# Altium schematic sheet mcu_main.SchDoc(mcu_main)
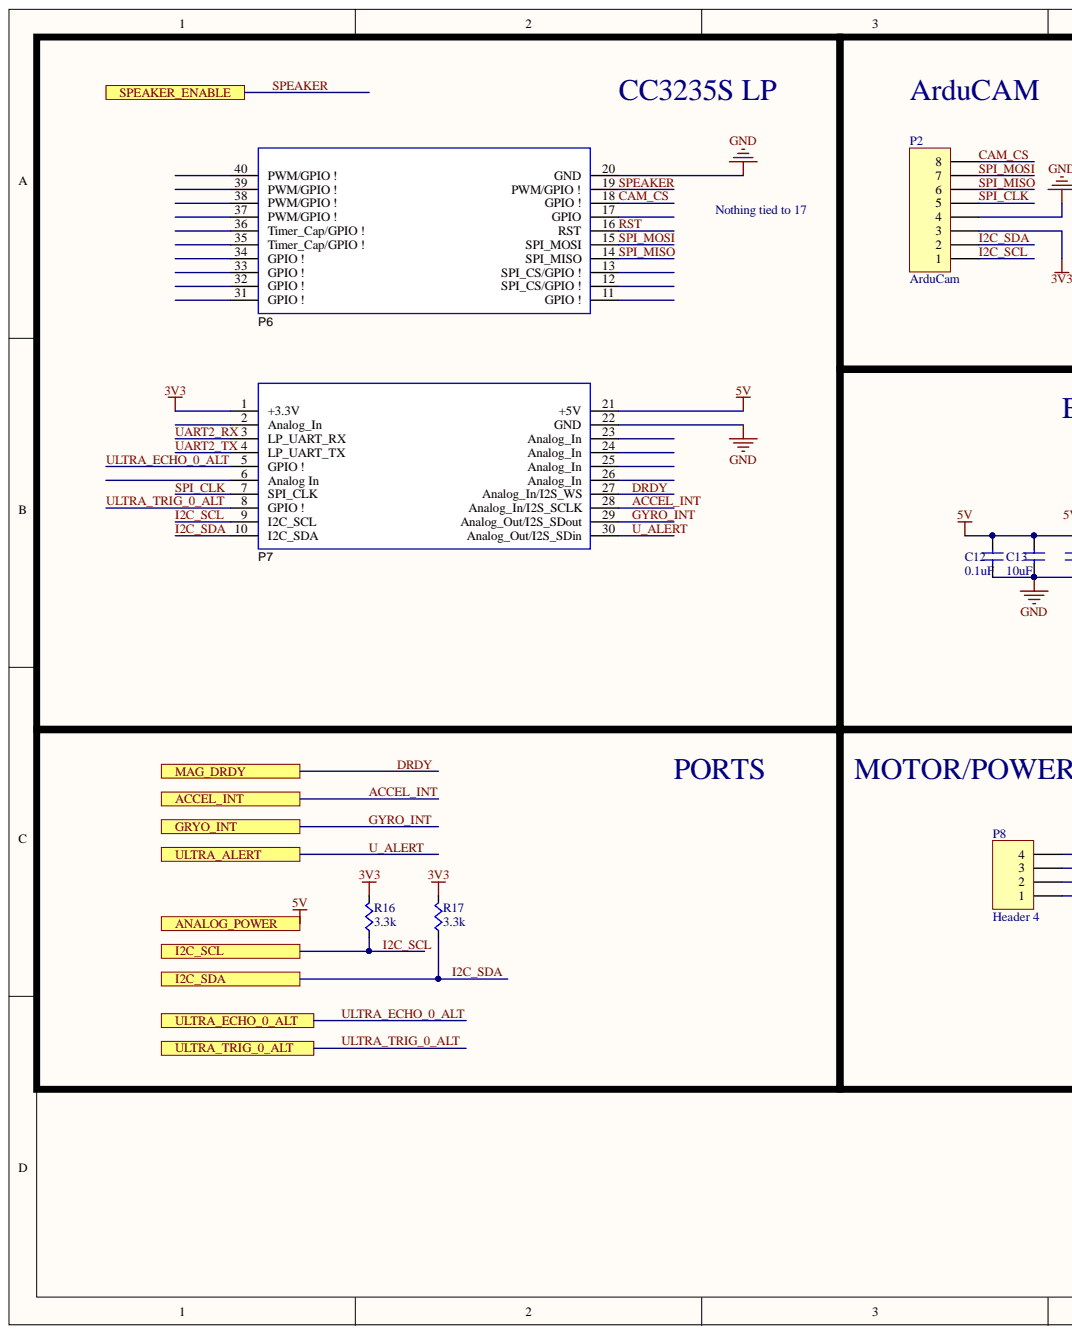
[diagram p0: mcu_main.SchDoc, left]
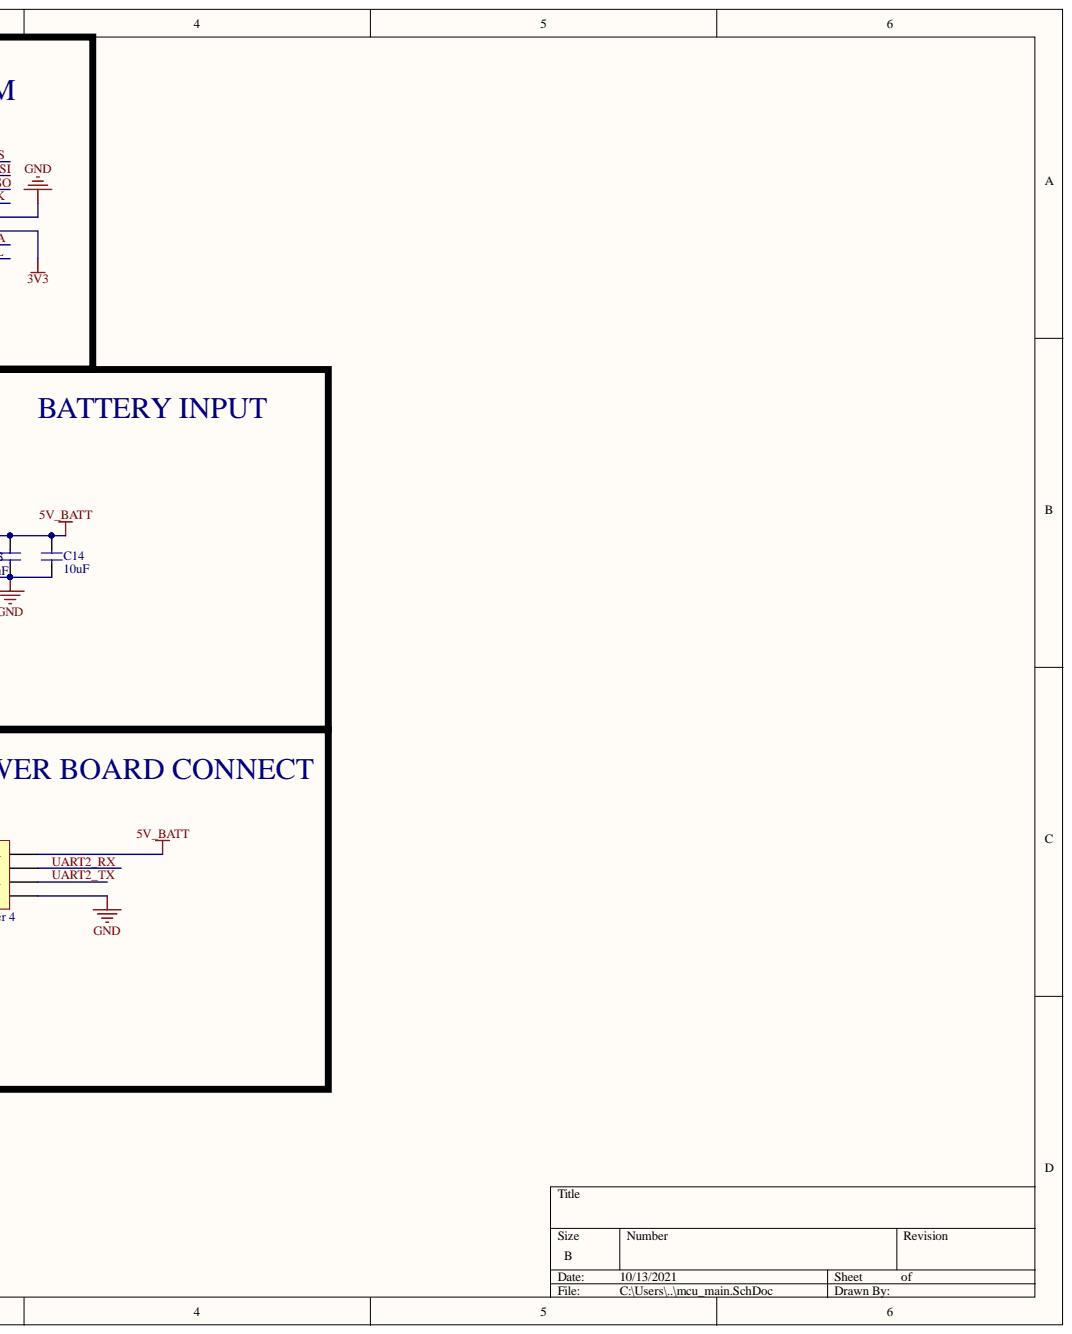
[diagram p1: mcu_main.SchDoc, right]

## Parts
C12  value 0.1uF  [CAPC0603]  (hidden comment: Cap)
C13  value 10uF  [CAPC0603]  (hidden comment: Cap)
C14  value 10uF  [CAPC0603]  (hidden comment: Cap)
P2  ArduCam  [HDR1X8]
P6  SSQ-110-03-T-D  [BoosterPack_40pin_J2J4]  (value not drawn)
P7  SSQ-110-03-T-D  [BoosterPack_40pin_J1J3]  (value not drawn)
P8  Header 4  [HDR1X4]
R16  value 3.3k  [RES0603]  (hidden comment: Res)
R17  value 3.3k  [RES0603]  (hidden comment: Res)

## Ports
ACCEL_INT, ANALOG_POWER, GRYO_INT, I2C_SCL, I2C_SDA, MAG_DRDY, SPEAKER_ENABLE, ULTRA_ALERT, ULTRA_ECHO_0_ALT, ULTRA_TRIG_0_ALT

## Nets
3V3: P2.3 P7.1(+3.3V) R16.1 R17.1
GND: C12.1 C13.1 C14.1 P2.4 P6.20(GND) P7.22(GND) P8.1
5V: C12.2 C13.2 C14.2 P7.21(+5V) P8.4 ; port ANALOG_POWER
ACCEL_INT: P7.28(Analog_In/I2S_SCLK) ; port ACCEL_INT
CAM_CS: P2.8 P6.18(GPIO_!)
DRDY: P7.27(Analog_In/I2S_WS) ; port MAG_DRDY
GYRO_INT: P7.29(Analog_Out/I2S_SDout) ; port GRYO_INT
I2C_SCL: P2.1 P7.9(I2C_SCL) R16.2 ; port I2C_SCL
I2C_SDA: P2.2 P7.10(I2C_SDA) R17.2 ; port I2C_SDA
RST: P6.16(RST)
SPEAKER: P6.19(PWM/GPIO_!) ; port SPEAKER_ENABLE
SPI_CLK: P2.5 P7.7(SPI_CLK)
SPI_MISO: P2.6 P6.14(SPI_MISO)
SPI_MOSI: P2.7 P6.15(SPI_MOSI)
U_ALERT: P7.30(Analog_Out/I2S_SDin) ; port ULTRA_ALERT
UART2_RX: P7.3(LP_UART_RX) P8.3
UART2_TX: P7.4(LP_UART_TX) P8.2
ULTRA_ECHO_0_ALT: P7.5(GPIO_!) ; port ULTRA_ECHO_0_ALT
ULTRA_TRIG_0_ALT: P7.8(GPIO_!) ; port ULTRA_TRIG_0_ALT

Not connected: P6 11(GPIO_!) 12(SPI_CS/GPIO_!) 13(SPI_CS/GPIO_!) 17(GPIO) 31(GPIO_!) 32(GPIO_!) 33(GPIO_!) 34(GPIO_!) 35(Timer_Cap/GPIO_!) 36(Timer_Cap/GPIO_!) 37(PWM/GPIO_!) 38(PWM/GPIO_!) 39(PWM/GPIO_!) 40(PWM/GPIO_!); P7 2(Analog_In) 6(Analog_In) 23(Analog_In) 24(Analog_In) 25(Analog_In) 26(Analog_In)

## Library data of the parts
P2 = Header 8: Header, 8-Pin; Signal Integrity: Connector; Library Name: Miscellaneous Connectors.IntLib; LatestRevisionNote: Re-released for DXP Platform.; LatestRevisionDate: 17-Jul-2002; Component Kind: Standard; Publisher: Altium Limited
P6 = CMP-0078266-2: Receptacle, 2.54mm, 10x2, Tin, TH; Manufacturer: Samtec; Alternate PartNumber: CRD-081413-A-G; Alternate Manufacturer: Major League Electronics; Note: Booster Pack 40 pin J2 and J4; Green_REACh: Incomplete; Green_Lifecycle: Active; Supplier Part Number 2: 11P8392; Supplier Part Number 1: SAM1204-10-ND; Supplier 2: Newark; Supplier 1: Digi-Key; RoHS: Y; PartNumber: SSQ-110-03-T-D; PackageReference: 10x2 Receptacle; MountType: TH; Library Name: MCU065E1_LAUNCHCC3235MODA.IntLib; Green_EU RoHS-2: Passed; Green_EU RoHS: Passed; CompType: Receptacle; ComponentLink1URL: https://www.samtec.com/ftppub/cpdf/SSQ-1XX-XX-XXX-X-XX-XXX-XX-X-MKT.pdf; ComponentLink1Description: Datasheet Link; Component Kind: Standard
P7 = CMP-0003837-2: Receptacle, 2.54mm, 10x2, Tin, TH; Manufacturer: Samtec; Alternate PartNumber: CRD-081413-A-G; Alternate Manufacturer: Major League Electronics; Note: Booster Pack 40 pin J1 and J3; Green_REACh: Incomplete; Green_Lifecycle: Active; Supplier Part Number 2: 11P8392; Supplier Part Number 1: SAM1204-10-ND; Supplier 2: Newark; Supplier 1: Digi-Key; RoHS: Y; PartNumber: SSQ-110-03-T-D; PackageReference: 10x2 Receptacle; MountType: TH; Library Name: MCU065E1_LAUNCHCC3235MODA.IntLib; Green_EU RoHS-2: Passed; Green_EU RoHS: Passed; CompType: Receptacle; ComponentLink1URL: https://www.samtec.com/ftppub/cpdf/SSQ-1XX-XX-XXX-X-XX-XXX-XX-X-MKT.pdf; ComponentLink1Description: Datasheet Link; Component Kind: Standard
P8 = Header 4: Header, 4-Pin; Signal Integrity: Connector; Library Name: Miscellaneous Connectors.IntLib; LatestRevisionNote: Re-released for DXP Platform.; LatestRevisionDate: 17-Jul-2002; Component Kind: Standard; Publisher: Altium Limited
C12-C14 = Cap: Supplier Part Number 1: 1276-1000-1-ND; Supplier 1: Digi-Key; Library Name: Passives.SchLib; Component Kind: Standard
R16 R17 = Res: Supplier Part Number 1: RMCF0603JT10K0CT-ND; Supplier 1: Digi-Key; Library Name: Passives.SchLib; Component Kind: Standard
